# Altium schematic sheet bminator.SchDoc (continued)

## Library data of the parts
C1-C4 C11-C15 C44 C46 C48 = GRT033C71C104KE01D: CAP CER 100NF 16V X7S 0201 AEC-Q200; Manufacturer: Murata; Category: Ceramic Capacitors; Component Kind: Standard; Manufacturer Part Number: GRT033C71C104KE01D; Pricing 1: 1=0.10000, 10=0.04400, 50=0.04400, 100=0.02000, 1000=0.01100, 10000=0.01000 (USD); RoHS: Yes; Stock 1: 277440; Supplier 1: Mouser; Supplier Part Number 1: 81-GRT033C71C104KE1D; Library Name: ddln-passives.SchLib
C5-C8 C37 C38 = GRT155R60J475ME13D: CAP CER 4.7UF 6.3V X5R 0402 AEC-Q200; Manufacturer: Murata; Tolerance: 20%; Capacitance: 4.7uF; Case Code (Imperial): 0402; Case Code (Metric): 1005; Case/Package: 0402; Category: Ceramic Capacitors; Component Kind: Standard; ComponentLink1Description: http://search.murata.co.jp/Ceramy/image/img/A01X/G101/ENG/GRT155R60J475ME13-01.pdf?src-supplier=Digi-Key; ComponentLink1URL: http://search.murata.co.jp/Ceramy/image/img/A01X/G101/ENG/GRT155R60J475ME13-01.pdf?src-supplier=Digi-Key; ComponentLink2Description: https://search.murata.co.jp/Ceramy/image/img/A01X/partnumbering_e_02.pdf?src-supplier=Digi-Key; ComponentLink2URL: https://search.murata.co.jp/Ceramy/image/img/A01X/partnumbering_e_02.pdf?src-supplier=Digi-Key; Length: 0.039inch; Manufacturer Part Number: GRT155R60J475ME13D; Max Operating Temperature: 85°C; Min Operating Temperature: -55°C; Mount: Surface Mount; Packaging: Tape and Reel; Pricing 1: 10000=0.04860, 20000=0.03920, 30000=0.03890 (USD); Ratings: AEC-Q200; RoHS: No; Stock 1: 10000; Supplier 1: Future Electronics; Supplier Part Number 1: 2131604; Thickness: 0.022inch; Value: 4.7µF; Voltage Rating: 6.3V; Width: 0.02inch; Library Name: ddln-passives.SchLib
C9 C10 = GRT0335C1E270JA02D: CAP CER 27pF 25V NP0 0201; Manufacturer: Murata; Category: Ceramic Capacitors; Component Kind: Standard; Manufacturer Part Number: GRT0335C1E270JA02D; Pricing 1: 1=0.10000, 10=0.04400, 50=0.04400, 100=0.02000, 1000=0.01100, 10000=0.01000 (USD); RoHS: Yes; Stock 1: 277440; Supplier 1: Mouser; Supplier Part Number 1: 81-GRT033C71C104KE1D; Library Name: ddln-paul.SchLib
C16-C18 C26-C28 = 060-00355-000: CAP,CER,X5R,10%,50V,0805,10UF ROHS; Manufacturer: Murata; Manufacturer P/N: GRM21BR61H106KE43L; Value (nF): 10000; Value (pF): 10000000; Value (µF): 10; Avidyne P/N: 060-00355-000; Component Kind: Standard; Package: C0805; TOLERANCE: 10%; Value: 10µF; Library Name: ddln-paul.SchLib
C19 C29 = 060-00348-000: CAP, CER, SMT,50V, 10%, X5R, 0603, 2.2uf; Manufacturer: Taiyo Yuden; Manufacturer P/N: UMK107BBJ225KA-T; Value (nF): 2200; Value (pF): 2200000; Value (µF): 2.2; Avidyne P/N: 060-00348-000; Component Kind: Standard; Cost: 0.0696; Package: C0603; TOLERANCE: 10%; Value: 2.2µF; Library Name: ddln-paul.SchLib
C20-C23 = GRT188R61C106KE13D: CAP CER 10UF 16V X5R 0603 AEC-Q200; Manufacturer: Murata; Tolerance: 10%; Capacitance: 10uF; Case Code (Imperial): 0603; Case Code (Metric): 1608; Case/Package: 0603; Category: Ceramic Capacitors; Component Kind: Standard; ComponentLink1Description: http://search.murata.co.jp/Ceramy/image/img/A01X/G101/ENG/GRT188R61C106KE13-01.pdf?src-supplier=Digi-Key; ComponentLink1URL: http://search.murata.co.jp/Ceramy/image/img/A01X/G101/ENG/GRT188R61C106KE13-01.pdf?src-supplier=Digi-Key; Length: 0.063inch; Manufacturer Part Number: GRT188R61C106KE13D; Max Operating Temperature: 85°C; Min Operating Temperature: -55°C; Mount: Surface Mount; Packaging: Tape and Reel; Pricing 1: 1=0.44000, 10=0.30200, 50=0.21180, 100=0.18150, 500=0.13612, 1000=0.11495 (USD); Ratings: AEC-Q200; RoHS: Yes; Stock 1: 99001; Supplier 1: Digi-Key; Supplier Part Number 1: 490-12317-1-ND; Thickness: 0.035inch; Voltage Rating: 16V; Width: 0.031inch; Library Name: ddln-passives.SchLib
C24 = 064-00028-025: CAP RF, HIQ, NPO, +/- 1%, 10GHZ, 200, 100V, 0603, 150PF, ROHS; Manufacturer: Vishay; Manufacturer P/N: VJ0603D151FXBA; Value (nF): 0.15; Value (pF): 150; Value (µF): 0.00015; Avidyne P/N: 064-00028-025; Component Kind: Standard; Cost: 0.18353; Package: C0603; TOLERANCE: 1%; Value: 150pF; Library Name: ddln-paul.SchLib
C25 = 060-00353-000: CAP,CER,X7R,10%,100V,0805,1.0UF ROHS; Manufacturer: AVX; Manufacturer P/N: 08051C105K4Z2A; Value (nF): 1000; Value (pF): 1000000; Value (µF): 1; Avidyne P/N: 060-00353-000; Component Kind: Standard; Cost: 0.11244; Library Name: Capacitors.SCHLIB; Package: C0805; TOLERANCE: 10%; Value: 1.0µF
C30 = 060-00158-003: CAP CER 10UF 50V 10% X7R 1210; Manufacturer: Murata; Manufacturer P/N: GRM32ER71H106KA12L; Value: 10µF; Value (nF): 10000; Value (pF): 10000000; Value (µF): 10; Avidyne P/N: 060-00158-003; Component Kind: Standard; Cost: 0.18564; Package: C1210; TOLERANCE: 10%; Library Name: ddln-paul.SchLib
C31 C32 = 060-00355-000: CAP,CER,X5R,10%,50V,0805,10UF ROHS; Manufacturer: Murata; Manufacturer P/N: GRM21BR61H106KE43L; Value: 10µF; Value (nF): 10000; Value (pF): 10000000; Value (µF): 10; Avidyne P/N: 060-00355-000; Component Kind: Standard; Package: C0805; TOLERANCE: 10%; Library Name: ddln-paul.SchLib
C33-C36 = GCM155R71H104KE02J: CAP CER 0.1UF 50V X7R 0402 AEC-Q200; Manufacturer: Murata Electronics; Capacitance: 0.1µF; Tolerance: ±10%; Applications: Automotive; Component Kind: Standard; ComponentLink1Description: Datasheet; ComponentLink1URL: https://search.murata.co.jp/Ceramy/image/img/A01X/G101/ENG/GCM155R71H104KE02-01.pdf; ComponentLink2Description: DigiKey Link; ComponentLink2URL: http://digikey.com/product-detail/en/murata-electronics/GCM155R71H104KE02J/490-14514-1-ND/6606120; Device Package: 0402 (1005 Metric); LastUpdated: 02/09/2020 01:02:03; Lifecycle Status: Active; Minimum Order: 1; Mounting Type: Surface Mount, MLCC; Operating Temperature: -55°C ~ 125°C; Package / Case: 0402 (1005 Metric); Packaging: TapeAndReel; Part Number: GCM155R71H104KE02J; Part Status: Active; PartId: 11356; Price: 0.01; Ratings: AEC-Q200; Series: GCM; Size / Dimension: 0.039" L x 0.020" W (1.00mm x 0.50mm); Supplier 1: DigiKey; Supplier Part Number 1: 490-14514-1-ND; Temperature Coefficient: X7R; Thickness (Max): 0.022" (0.55mm); Value: 100nF; Library Name: ddln-passives.SchLib
  C33-C35: Voltage - Rated: 50V
C39 C42 C43 = GCM155C71A105ME38D: CAP CER 1UF 10V X7S 0402 AEC-Q200; Manufacturer: Murata; Category: Ceramic Capacitors; Component Kind: Standard; ComponentLink1Description: https://search.murata.co.jp/Ceramy/image/img/A01X/G101/ENG/GCM155C71A105ME38-01.pdf?src-supplier=Digi-Key; ComponentLink1URL: https://search.murata.co.jp/Ceramy/image/img/A01X/G101/ENG/GCM155C71A105ME38-01.pdf?src-supplier=Digi-Key; Manufacturer Part Number: GCM155C71A105ME38D; Pricing 1: 1=0.14000, 10=0.09700, 50=0.05520, 100=0.04580, 500=0.03210, 1000=0.02658, 2500=0.02474, 5000=0.02263 (USD); RoHS: Yes; RoHS Compliant: Yes; Stock 1: 84115; Supplier 1: Digi-Key; Supplier Part Number 1: 490-18202-1-ND; Value: 1µF; Library Name: ddln-passives.SchLib
C40 C41 C45 = 02013C103MAT2A: CAP CER 10NF 25V X7R 0201 AEC-Q200; Manufacturer: Murata; Manufacturer Part Number: GRT033C71C104KE01D; Category: Ceramic Capacitors; Component Kind: Standard; Pricing 1: 1=0.10000, 10=0.04400, 50=0.04400, 100=0.02000, 1000=0.01100, 10000=0.01000 (USD); RoHS: Yes; Stock 1: 277440; Supplier 1: Mouser; Supplier Part Number 1: 81-GRT033C71C104KE1D; Library Name: ddln-paul.SchLib
C47 = GRM155R71H472KA01D: CAP CER 4700PF 50V X7R 0402; Manufacturer: Murata Electronics; Tolerance: ±10%; Applications: General Purpose; Capacitance: 4700pF; Component Kind: Standard; ComponentLink1Description: Datasheet; ComponentLink1URL: https://www.murata.com/~/media/webrenewal/support/library/catalog/products/capacitor/mlcc/c02e.ashx?la=en-us; ComponentLink2Description: DigiKey Link; ComponentLink2URL: http://digikey.com/product-detail/en/murata-electronics/GRM155R71H472KA01D/490-1308-1-ND/587946; Device Package: 0402 (1005 Metric); LastUpdated: 02/09/2020 01:02:19; Lifecycle Status: Active; Minimum Order: 1; Mounting Type: Surface Mount, MLCC; Operating Temperature: -55°C ~ 125°C; Package / Case: 0402 (1005 Metric); Packaging: CutTape; Part Number: GRM155R71H472KA01D; Part Status: Active; PartId: 4000; Price: 0.10; Series: GRM; Size / Dimension: 0.039" L x 0.020" W (1.00mm x 0.50mm); Supplier 1: DigiKey; Supplier Part Number 1: 490-1308-1-ND; Temperature Coefficient: X7R; Thickness (Max): 0.022" (0.55mm); Value: 4700pF; Voltage - Rated: 50V; Library Name: ddln-passives.SchLib
D1 = VLMS1500-GS08: LED RED 0402 SMD; Manufacturer: Vishay; Dominant Wavelength: 631nm; Forward Current: 30mA; Lens Style: Rectangular,Square; Luminous Intensity: 54mcd; Number of LEDs: 1; Power Rating: 75mW; Viewing Angle: 130°; Case Code (Imperial): 0402; Case Code (Metric): 1005; Case/Package: 0402; Category: LEDs; Color: Red; Component Kind: Standard; ComponentLink1Description: https://datasheet.ciiva.com/13945/vlmo1500-13945369.pdf?src-supplier=Digi-Key; ComponentLink1URL: https://datasheet.ciiva.com/13945/vlmo1500-13945369.pdf?src-supplier=Digi-Key; ComponentLink2Description: https://datasheet.ciiva.com/13945/vlmo1500-13945367.pdf?src-supplier=Digi-Key; ComponentLink2URL: https://datasheet.ciiva.com/13945/vlmo1500-13945367.pdf?src-supplier=Digi-Key; ComponentLink3Description: https://datasheet.ciiva.com/13945/vlmo1500-13945368.pdf?src-supplier=Digi-Key; ComponentLink3URL: https://datasheet.ciiva.com/13945/vlmo1500-13945368.pdf?src-supplier=Digi-Key; Height: 0.35mm; Illumination Color: Red; Lead Free: Lead Free; Length: 1mm; Manufacturer Part Number: VLMS1500-GS08; Max Operating Temperature: 85°C; Min Operating Temperature: -30°C; Mount: Surface Mount; Number of Pins: 2; Package Quantity: 1; Packaging: Tape and Reel; Pricing 1: 1=0.43000, 10=0.32200, 100=0.18250, 500=0.12088, 1000=0.09267 (USD); Radiation Hardening: No; REACH SVHC: Unknown; RoHS: Yes; RoHS Compliant: Yes; Series: CHipLED; Stock 1: 9946; Supplier 1: Digi-Key; Supplier Part Number 1: VLMS1500-GS08CT-ND; Wavelength: 631nm; Width: 0.5mm; Library Name: ddln-diodes-transistors.SchLib
D2 = 023-00382-000: DIODE SCHOTTKY 60V 2A CFP3; Manufacturer: NXP; Manufacturer P/N: PMEG6020AELRX; Value: PMEG6020; Vf: 670mV @ 2A; Wattage: 1W; Avidyne P/N: 023-00382-000; Component Kind: Standard; Cost: 0.09842; Package: SOD-123W; Type: Schottky; Library Name: ddln-paul.SchLib
FB1-FB4 = 082-00033-000: FERRITE,100MHZ,0402,120OHM ROHS; Manufacturer: TDK; Manufacturer P/N: MPZ1005S121HT000; Impedance: 120 Ohm @ 100MHz; Value: MPZ1005S121HT000; Avidyne P/N: 082-00033-000; Component Kind: Standard; Cost: 0.03168; Package: L0402; Simulation: FB; Library Name: ddln-paul.SchLib
IC1 = STM32L431: Integrated Circuit; Manufacturer_Name: STMicroelectronics; Manufacturer_Part_Number: STM32F103C8T6TR; Arrow Part Number: STM32F103C8T6TR; Arrow Price/Stock: https://www.arrow.com/en/products/stm32f103c8t6tr/stmicroelectronics?region=europe; Component Kind: Standard; Datasheet Link: http://media.digikey.com/pdf/Data%20Sheets/ST%20Microelectronics%20PDFS/STM32F103x8,B.pdf; Height: 1.6mm; Mouser Part Number: 511-STM32F103C8T6TR; Mouser Price/Stock: https://www.mouser.co.uk/ProductDetail/STMicroelectronics/STM32F103C8T6TR?qs=5HwTSiuA5HDxFj62n06yag%3D%3D; Library Name: ddln-paul.SchLib
IC2 = LMQ66410MC5RXBRQ1: Integrated Circuit; Manufacturer_Name: Texas Instruments; Arrow Part Number: LMQ66410MC5RXBRQ1; Arrow Price/Stock: https://www.arrow.com/en/products/lmq66410mc5rxbrq1/texas-instruments?region=nac; Component Kind: Standard; Datasheet Link: https://www.ti.com/lit/ds/symlink/lmq66410.pdf?ts=1695019454011&ref_url=https%253A%252F%252Fwww.ti.com%252Fpower-management%252Fnon-isolated-dc-dc-switching-regulators%252Fstep-down-buck%252Fbuck-converter-integrated-switch%252Fproducts.html; Height: 1.05mm; Manufacturer_Part_Number: LMQ66410MC5RXBRQ1; Mouser Part Number: 595-MQ66410MC5RXBRQ1; Mouser Price/Stock: https://www.mouser.co.uk/ProductDetail/Texas-Instruments/LMQ66410MC5RXBRQ1?qs=1Kr7Jg1SGW8IS8%252BO4rEnbw%3D%3D; Library Name: ddln-paul.SchLib
J1 = 3-PIN-POGO: Made for pressing spring loaded pins for CAN.; Manufacturer_Name: Molex; Component Kind: Standard; Datasheet Link: http://www.farnell.com/datasheets/2695377.pdf; Height: 8.38mm; Manufacturer_Part_Number: 22-28-4030; Mouser Part Number: 538-22-28-4030; Mouser Price/Stock: https://www.mouser.co.uk/ProductDetail/Molex/22-28-4030?qs=d9fIA1aNikRAvd6m1950OA%3D%3D; Library Name: ddln-paul.SchLib
J2 = 6-PIN-POGO: Made for pressing spring loaded pins against for programming; Manufacturer_Name: Molex; Component Kind: Standard; Datasheet Link: http://www.farnell.com/datasheets/2695377.pdf; Height: 8.38mm; Manufacturer_Part_Number: 22-28-4030; Mouser Part Number: 538-22-28-4030; Mouser Price/Stock: https://www.mouser.co.uk/ProductDetail/Molex/22-28-4030?qs=d9fIA1aNikRAvd6m1950OA%3D%3D; Library Name: ddln-paul.SchLib
J3 = HR10A-10R-12PB(72): 12 Position Circular Connector Receptacle, Male Pins Solder; MF: Hirose Electric; MP: HR10A-10R-12PB_72_; Component Kind: Standard; Digi-Key_Part_Number: HR1650-ND; Digi-Key_Purchase_URL: https://www.digikey.kr/product-detail/en/hirose-electric-co-ltd/HR10A-10R-12PB_72_/HR1650-ND/1095508?utm_source=snapeda&utm_medium=aggregator&utm_campaign=symbol; Package: None; SnapEDA_Link: https://www.snapeda.com/parts/HR10A-10R-12PB_72_/Hirose%20Electric%20Co%20Ltd/view-part/493204/?ref=snap; Library Name: ddln-paul.SchLib
L1 = LQH5BPZ4R7NT0L: Inductor; Manufacturer_Name: Murata Electronics; Arrow Part Number: LQH5BPZ4R7NT0L; Arrow Price/Stock: https://www.arrow.com/en/products/lqh5bpz4r7nt0l/murata-manufacturing; Component Kind: Standard; Datasheet Link: https://psearch.en.murata.com/inductor/product/LQH5BPZ4R7NT0%23.html; Height: 2.2mm; Manufacturer_Part_Number: LQH5BPZ4R7NT0L; Mouser Part Number: 81-LQH5BPZ4R7NT0L; Mouser Price/Stock: https://www.mouser.co.uk/ProductDetail/Murata-Electronics/LQH5BPZ4R7NT0L?qs=Ey7%2FXF42M3e2lK8EunBAqA%3D%3D; Library Name: ddln-paul.SchLib
L2 = LQH32PBR47NN0L: Inductor; Manufacturer_Name: Murata Electronics; Manufacturer_Part_Number: LQH5BPZ4R7NT0L; Arrow Part Number: LQH5BPZ4R7NT0L; Arrow Price/Stock: https://www.arrow.com/en/products/lqh5bpz4r7nt0l/murata-manufacturing; Component Kind: Standard; Datasheet Link: https://psearch.en.murata.com/inductor/product/LQH5BPZ4R7NT0%23.html; Height: 2.2mm; Mouser Part Number: 81-LQH5BPZ4R7NT0L; Mouser Price/Stock: https://www.mouser.co.uk/ProductDetail/Murata-Electronics/LQH5BPZ4R7NT0L?qs=Ey7%2FXF42M3e2lK8EunBAqA%3D%3D; Library Name: ddln-paul.SchLib
L3 = 080-00283-000: INDUCT ARRAY 2 COIL 3.3UH SMD; Core: Metal Composite; Current: 1.66A; Current_Sat: 2.11A; TOLERANCE: 20%; Avidyne P/N: 080-00283-000; Component Kind: Standard; Cost: 0.51750; Library Name: E1V2 Schlib.SchLib; Package: 0.299" L x 0.299" W (7.60mm x 7.60mm); Value: 3.3uH
R1 R17 = ERJ-1GNF1002C: RES SMD 10K OHM 1% 1/20W 0201; Manufacturer: Panasonic Electronic Components; Power (Watts): 0.05W, 1/20W; Resistance: 10 kOhms; Temperature Coefficient: ±200ppm/°C; Component Kind: Standard; ComponentLink1Description: Datasheet; ComponentLink1URL: https://industrial.panasonic.com/cdbs/www-data/pdf/RDA0000/AOA0000C304.pdf; ComponentLink2Description: DigiKey Link; ComponentLink2URL: http://digikey.com/product-detail/en/panasonic-electronic-components/ERJ-1GNF1002C/P122414CT-ND/7389440; Composition: Thick Film; Device Package: 0201 (0603 Metric); Features: Automotive AEC-Q200; Height - Seated (Max): 0.010" (0.26mm); LastUpdated: 02/09/2020 01:06:16; Lifecycle Status: Active; Minimum Order: 1; Number of Terminations: 2; Operating Temperature: -55°C ~ 125°C; Package / Case: 0201 (0603 Metric); Packaging: TapeAndReel; Part Number: ERJ-1GNF1002C; Part Status: Active; PartId: 2296; Price: 0.01; Ratings: AEC-Q200; Series: ERJ; Size / Dimension: 0.024" L x 0.012" W (0.60mm x 0.30mm); Supplier 1: DigiKey; Supplier Device Package: 0201; Supplier Part Number 1: P122414CT-ND; Tolerance: ±1%; Value: 10 kΩ; Library Name: ddln-res-0201.SchLib
R2 R8 = ERJ-1GEF1001C: RES SMD 1K OHM 1% 1/20W 0201; Manufacturer: Panasonic Electronic Components; Power (Watts): 0.05W, 1/20W; Resistance: 1 kOhms; Temperature Coefficient: ±200ppm/°C; Component Kind: Standard; ComponentLink1Description: Datasheet; ComponentLink1URL: //media.digikey.com/pdf/Data%20Sheets/Panasonic%20Resistors%20Thermistors%20PDFs/ERJ-G,R,E_Series_Rev_Oct_2014.pdf; ComponentLink2Description: DigiKey Link; ComponentLink2URL: http://digikey.com/product-detail/en/panasonic-electronic-components/ERJ-1GEF1001C/P1-00KABCT-ND/525077; Composition: Thick Film; Height - Seated (Max): 0.010" (0.26mm); LastUpdated: 02/09/2020 01:06:09; Lifecycle Status: Active; Minimum Order: 1; Number of Terminations: 2; Package / Case: 0201 (0603 Metric); Packaging: CutTape; Part Number: ERJ-1GEF1001C; PartId: 57331; Price: 0.10; Series: ERJ; Size / Dimension: 0.024" L x 0.012" W (0.60mm x 0.30mm); Supplier 1: DigiKey; Supplier Device Package: 0201; Supplier Part Number 1: P1.00KABCT-ND; Tolerance: ±1%; Value: 1 kΩ; Library Name: ddln-res-0201.SchLib
R3 = RMCF0402FT820R: RES 820 OHM 1% 1/16W 0402; Manufacturer: Stackpole Electronics Inc; Power (Watts): 0.063W, 1/16W; Temperature Coefficient: ±100ppm/°C; Component Kind: Standard; ComponentLink1Description: Datasheet; ComponentLink1URL: https://www.seielect.com/catalog/sei-rmcf_rmcp.pdf; ComponentLink2Description: DigiKey Link; ComponentLink2URL: http://digikey.com/product-detail/en/stackpole-electronics-inc/RMCF0402FT820R/RMCF0402FT820RCT-ND/6052949; Composition: Thick Film; Device Package: 0402 (1005 Metric); Features: Automotive AEC-Q200; Height - Seated (Max): 0.016" (0.40mm); LastUpdated: 02/09/2020 01:07:36; Lifecycle Status: Active; Minimum Order: 1; Number of Terminations: 2; Operating Temperature: -55°C ~ 155°C; Package / Case: 0402 (1005 Metric); Packaging: CutTape; Part Number: RMCF0402FT820R; Part Status: Active; PartId: 17897; Price: 0.10; Ratings: AEC-Q200; Resistance: 820 Ω; Series: RMCF; Size / Dimension: 0.039" L x 0.020" W (1.00mm x 0.50mm); Supplier 1: DigiKey; Supplier Device Package: 0402; Supplier Part Number 1: RMCF0402FT820RCT-ND; Tolerance: ±1%; Value: 820 Ω; Library Name: ddln-res-0402.SchLib
R4 R15 = RMCF0402FT475R: RES 475 OHM 1% 1/16W 0402; Manufacturer: Stackpole Electronics Inc; Power (Watts): 0.063W, 1/16W; Temperature Coefficient: ±100ppm/°C; Component Kind: Standard; ComponentLink1Description: Datasheet; ComponentLink1URL: https://www.seielect.com/catalog/sei-rmcf_rmcp.pdf; ComponentLink2Description: DigiKey Link; ComponentLink2URL: http://digikey.com/product-detail/en/stackpole-electronics-inc/RMCF0402FT475R/RMCF0402FT475RCT-ND/2417702; Composition: Thick Film; Device Package: 0402 (1005 Metric); Features: Automotive AEC-Q200; Height - Seated (Max): 0.016" (0.40mm); LastUpdated: 02/09/2020 01:07:52; Lifecycle Status: Active; Minimum Order: 1; Number of Terminations: 2; Operating Temperature: -55°C ~ 155°C; Package / Case: 0402 (1005 Metric); Packaging: CutTape; Part Number: RMCF0402FT475R; Part Status: Active; PartId: 10933; Price: 0.10; Ratings: AEC-Q200; Resistance: 475 Ω; Series: RMCF; Size / Dimension: 0.039" L x 0.020" W (1.00mm x 0.50mm); Supplier 1: DigiKey; Supplier Device Package: 0402; Supplier Part Number 1: RMCF0402FT475RCT-ND; Tolerance: ±1%; Value: 475 Ω; Library Name: ddln-res-0402.SchLib
R5 = 070-00605-001: RES, THICK FILM CHIP, 1W, 1%, 1206, 0.01 OHM; Manufacturer: Vishay-Dale Intertechnology, Inc; Manufacturer P/N: WSLP1206R0100FEA; Avidyne P/N: 070-00605-001; Component Kind: Standard; Cost: 0.25; Package: R1206; Simulation: R; Library Name: ddln-paul.SchLib
R6 = RMCF0402FT301R: RES 301 OHM 1% 1/16W 0402; Manufacturer: Stackpole Electronics Inc; Power (Watts): 0.063W, 1/16W; Temperature Coefficient: ±100ppm/°C; Component Kind: Standard; ComponentLink1Description: Datasheet; ComponentLink1URL: https://www.seielect.com/catalog/sei-packaging.pdf; ComponentLink2Description: DigiKey Link; ComponentLink2URL: http://digikey.com/product-detail/en/stackpole-electronics-inc/RMCF0402FT301R/RMCF0402FT301RCT-ND/4250676; Composition: Thick Film; Device Package: 0402 (1005 Metric); Features: Automotive AEC-Q200; Height - Seated (Max): 0.016" (0.40mm); LastUpdated: 02/09/2020 01:07:36; Lifecycle Status: Active; Minimum Order: 1; Number of Terminations: 2; Operating Temperature: -55°C ~ 155°C; Package / Case: 0402 (1005 Metric); Packaging: CutTape; Part Number: RMCF0402FT301R; Part Status: Active; PartId: 17904; Price: 0.10; Ratings: AEC-Q200; Resistance: 301 Ω; Series: RMCF; Size / Dimension: 0.039" L x 0.020" W (1.00mm x 0.50mm); Supplier 1: DigiKey; Supplier Device Package: 0402; Supplier Part Number 1: RMCF0402FT301RCT-ND; Tolerance: ±1%; Value: 301 Ω; Library Name: ddln-res-0402.SchLib
R7 = RMCF0402ZT0R00: RES 0 OHM JUMPER 1/16W 0402; Manufacturer: Stackpole Electronics Inc; Power (Watts): 0.063W, 1/16W; Component Kind: Standard; ComponentLink1Description: Datasheet; ComponentLink1URL: https://www.seielect.com/catalog/sei-rmcf_rmcp.pdf; ComponentLink2Description: DigiKey Link; ComponentLink2URL: http://digikey.com/product-detail/en/stackpole-electronics-inc/RMCF0402ZT0R00/RMCF0402ZT0R00CT-ND/1942941; Composition: Thick Film; Device Package: 0402 (1005 Metric); Features: Automotive AEC-Q200; Height - Seated (Max): 0.016" (0.40mm); LastUpdated: 02/09/2020 01:07:36; Lifecycle Status: Active; Minimum Order: 1; Number of Terminations: 2; Operating Temperature: -55°C ~ 155°C; Package / Case: 0402 (1005 Metric); Packaging: CutTape; Part Number: RMCF0402ZT0R00; Part Status: Active; PartId: 17627; Price: 0.10; Ratings: AEC-Q200; Resistance: 0 Ω; Series: RMCF; Size / Dimension: 0.039" L x 0.020" W (1.00mm x 0.50mm); Supplier 1: DigiKey; Supplier Device Package: 0402; Supplier Part Number 1: RMCF0402ZT0R00CT-ND; Tolerance: Jumper; Value: 0 Ω; Library Name: ddln-res-0402.SchLib
R9 = ERJ-1GNF1212C: RES SMD 12.1K OHM 1% 1/20W 0201; Manufacturer: Panasonic Electronic Components; Power (Watts): 0.05W, 1/20W; Resistance: 12.1 kOhms; Temperature Coefficient: ±200ppm/°C; Component Kind: Standard; ComponentLink1Description: Datasheet; ComponentLink1URL: https://industrial.panasonic.com/cdbs/www-data/pdf/RDA0000/AOA0000C304.pdf; ComponentLink2Description: DigiKey Link; ComponentLink2URL: http://digikey.com/product-detail/en/panasonic-electronic-components/ERJ-1GNF1212C/P122698CT-ND/8342335; Composition: Thick Film; Device Package: 0201 (0603 Metric); Features: Automotive AEC-Q200; Height - Seated (Max): 0.010" (0.26mm); LastUpdated: 02/09/2020 01:06:16; Lifecycle Status: Active; Minimum Order: 1; Number of Terminations: 2; Operating Temperature: -55°C ~ 125°C; Package / Case: 0201 (0603 Metric); Packaging: TapeAndReel; Part Number: ERJ-1GNF1212C; Part Status: Active; PartId: 2364; Price: 0.01; Ratings: AEC-Q200; Series: ERJ; Size / Dimension: 0.024" L x 0.012" W (0.60mm x 0.30mm); Supplier 1: DigiKey; Supplier Device Package: 0201; Supplier Part Number 1: P122698CT-ND; Tolerance: ±1%; Value: 12.1 kΩ; Library Name: ddln-res-0201.SchLib
R10 = RMCF0402FT1K00: RES 1K OHM 1% 1/16W 0402; Manufacturer: Stackpole Electronics Inc; Power (Watts): 0.063W, 1/16W; Temperature Coefficient: ±100ppm/°C; Component Kind: Standard; ComponentLink1Description: Datasheet; ComponentLink1URL: https://www.seielect.com/catalog/sei-rmcf_rmcp.pdf; ComponentLink2Description: DigiKey Link; ComponentLink2URL: http://digikey.com/product-detail/en/stackpole-electronics-inc/RMCF0402FT1K00/RMCF0402FT1K00CT-ND/1942920; Composition: Thick Film; Device Package: 0402 (1005 Metric); Features: Automotive AEC-Q200; Height - Seated (Max): 0.016" (0.40mm); LastUpdated: 02/09/2020 01:07:28; Lifecycle Status: Active; Minimum Order: 1; Number of Terminations: 2; Operating Temperature: -55°C ~ 155°C; Package / Case: 0402 (1005 Metric); Packaging: CutTape; Part Number: RMCF0402FT1K00; Part Status: Active; PartId: 20544; Price: 0.10; Ratings: AEC-Q200; Resistance: 1 kΩ; Series: RMCF; Size / Dimension: 0.039" L x 0.020" W (1.00mm x 0.50mm); Supplier 1: DigiKey; Supplier Device Package: 0402; Supplier Part Number 1: RMCF0402FT1K00CT-ND; Tolerance: ±1%; Value: 1 kΩ; Library Name: ddln-res-0402.SchLib
R11 = ERJ-1GNF1003C: RES SMD 100K OHM 1% 1/20W 0201; Manufacturer: Panasonic Electronic Components; Power (Watts): 0.05W, 1/20W; Temperature Coefficient: ±200ppm/°C; Component Kind: Standard; ComponentLink1Description: Datasheet; ComponentLink1URL: https://industrial.panasonic.com/cdbs/www-data/pdf/RDA0000/AOA0000C304.pdf; ComponentLink2Description: DigiKey Link; ComponentLink2URL: http://digikey.com/product-detail/en/panasonic-electronic-components/ERJ-1GNF1003C/P122655CT-ND/8342249; Composition: Thick Film; Device Package: 0201 (0603 Metric); Features: Automotive AEC-Q200; Height - Seated (Max): 0.010" (0.26mm); LastUpdated: 02/09/2020 01:06:22; Lifecycle Status: Active; Minimum Order: 1; Number of Terminations: 2; Operating Temperature: -55°C ~ 125°C; Package / Case: 0201 (0603 Metric); Packaging: TapeAndReel; Part Number: ERJ-1GNF1003C; Part Status: Active; PartId: 819; Price: 0.01; Ratings: AEC-Q200; Series: ERJ; Size / Dimension: 0.024" L x 0.012" W (0.60mm x 0.30mm); Supplier 1: DigiKey; Supplier Device Package: 0201; Supplier Part Number 1: P122655CT-ND; Tolerance: ±1%; Value: 100 kΩ; Library Name: ddln-res-0201.SchLib
R12 = ERJ-1GNF1003C: RES SMD 100K OHM 1% 1/20W 0201; Manufacturer: Panasonic Electronic Components; Power (Watts): 0.05W, 1/20W; Resistance: 100 kOhms; Temperature Coefficient: ±200ppm/°C; Component Kind: Standard; ComponentLink1Description: Datasheet; ComponentLink1URL: https://industrial.panasonic.com/cdbs/www-data/pdf/RDA0000/AOA0000C304.pdf; ComponentLink2Description: DigiKey Link; ComponentLink2URL: http://digikey.com/product-detail/en/panasonic-electronic-components/ERJ-1GNF1003C/P122655CT-ND/8342249; Composition: Thick Film; Device Package: 0201 (0603 Metric); Features: Automotive AEC-Q200; Height - Seated (Max): 0.010" (0.26mm); LastUpdated: 02/09/2020 01:06:22; Lifecycle Status: Active; Minimum Order: 1; Number of Terminations: 2; Operating Temperature: -55°C ~ 125°C; Package / Case: 0201 (0603 Metric); Packaging: TapeAndReel; Part Number: ERJ-1GNF1003C; Part Status: Active; PartId: 819; Price: 0.01; Ratings: AEC-Q200; Series: ERJ; Size / Dimension: 0.024" L x 0.012" W (0.60mm x 0.30mm); Supplier 1: DigiKey; Supplier Device Package: 0201; Supplier Part Number 1: P122655CT-ND; Tolerance: ±1%; Value: 100 kΩ; Library Name: ddln-res-0201.SchLib
R13 = ERJ-1GNF2211C: RES SMD 2.21K OHM 1% 1/20W 0201; Manufacturer: Panasonic Electronic Components; Power (Watts): 0.05W, 1/20W; Resistance: 2.21 kOhms; Temperature Coefficient: ±200ppm/°C; Component Kind: Standard; ComponentLink1Description: Datasheet; ComponentLink1URL: https://industrial.panasonic.com/cdbs/www-data/pdf/RDA0000/AOA0000C304.pdf; ComponentLink2Description: DigiKey Link; ComponentLink2URL: http://digikey.com/product-detail/en/panasonic-electronic-components/ERJ-1GNF2211C/P122836CT-ND/8342611; Composition: Thick Film; Device Package: 0201 (0603 Metric); Features: Automotive AEC-Q200; Height - Seated (Max): 0.010" (0.26mm); LastUpdated: 02/09/2020 01:06:11; Lifecycle Status: Active; Minimum Order: 1; Number of Terminations: 2; Operating Temperature: -55°C ~ 125°C; Package / Case: 0201 (0603 Metric); Packaging: TapeAndReel; Part Number: ERJ-1GNF2211C; Part Status: Active; PartId: 4111; Price: 0.01; Ratings: AEC-Q200; Series: ERJ; Size / Dimension: 0.024" L x 0.012" W (0.60mm x 0.30mm); Supplier 1: DigiKey; Supplier Device Package: 0201; Supplier Part Number 1: P122836CT-ND; Tolerance: ±1%; Value: 2.21 kΩ; Library Name: ddln-res-0201.SchLib
R14 = ERJ-1GNF2002C: RES SMD 20K OHM 1% 1/20W 0201; Manufacturer: Panasonic Electronic Components; Power (Watts): 0.05W, 1/20W; Resistance: 20 kOhms; Temperature Coefficient: ±200ppm/°C; Component Kind: Standard; ComponentLink1Description: Datasheet; ComponentLink1URL: https://industrial.panasonic.com/cdbs/www-data/pdf/RDA0000/AOA0000C304.pdf; ComponentLink2Description: DigiKey Link; ComponentLink2URL: http://digikey.com/product-detail/en/panasonic-electronic-components/ERJ-1GNF2002C/P122813CT-ND/8342565; Composition: Thick Film; Device Package: 0201 (0603 Metric); Features: Automotive AEC-Q200; Height - Seated (Max): 0.010" (0.26mm); LastUpdated: 02/09/2020 01:06:11; Lifecycle Status: Active; Minimum Order: 1; Number of Terminations: 2; Operating Temperature: -55°C ~ 125°C; Package / Case: 0201 (0603 Metric); Packaging: TapeAndReel; Part Number: ERJ-1GNF2002C; Part Status: Active; PartId: 4105; Price: 0.01; Ratings: AEC-Q200; Series: ERJ; Size / Dimension: 0.024" L x 0.012" W (0.60mm x 0.30mm); Supplier 1: DigiKey; Supplier Device Package: 0201; Supplier Part Number 1: P122813CT-ND; Tolerance: ±1%; Value: 20 kΩ; Library Name: ddln-res-0201.SchLib
R16 = ERJ-1GNF2742C: RES SMD 27.4K OHM 1% 1/20W 0201; Manufacturer: Panasonic Electronic Components; Power (Watts): 0.05W, 1/20W; Resistance: 27.4 kOhms; Temperature Coefficient: ±200ppm/°C; Component Kind: Standard; ComponentLink1Description: Datasheet; ComponentLink1URL: https://industrial.panasonic.com/cdbs/www-data/pdf/RDA0000/AOA0000C304.pdf; ComponentLink2Description: DigiKey Link; ComponentLink2URL: http://digikey.com/product-detail/en/panasonic-electronic-components/ERJ-1GNF2742C/P122892CT-ND/8342723; Composition: Thick Film; Device Package: 0201 (0603 Metric); Features: Automotive AEC-Q200; Height - Seated (Max): 0.010" (0.26mm); LastUpdated: 02/09/2020 01:06:16; Lifecycle Status: Active; Minimum Order: 1; Number of Terminations: 2; Operating Temperature: -55°C ~ 125°C; Package / Case: 0201 (0603 Metric); Packaging: TapeAndReel; Part Number: ERJ-1GNF2742C; Part Status: Active; PartId: 2321; Price: 0.01; Ratings: AEC-Q200; Series: ERJ; Size / Dimension: 0.024" L x 0.012" W (0.60mm x 0.30mm); Supplier 1: DigiKey; Supplier Device Package: 0201; Supplier Part Number 1: P122892CT-ND; Tolerance: ±1%; Value: 27.4 kΩ; Library Name: ddln-res-0201.SchLib
SJ1 = DualSolderJumper: Power Supply; Manufacturer_Name: RECOM Power; Manufacturer_Part_Number: R-78E3.3-1.0; Arrow Part Number: R-78E3.3-1.0; Arrow Price/Stock: https://www.arrow.com/en/products/r-78e3.3-1.0/recom-power?region=europe; Component Kind: Standard; Datasheet Link: https://componentsearchengine.com/Datasheets/1/R-78E3.3-1.0.pdf; Height: 10.9mm; Mouser Part Number: 919-R-78E3.3-1.0; Mouser Price/Stock: https://www.mouser.co.uk/ProductDetail/RECOM-Power/R-78E3.3-1.0?qs=D0iH%252BVFiYPOgLjjtWWnV0Q%3D%3D; Library Name: ddln-paul.SchLib
U1 = BME280: DIGITAL HUMIDITY, PRESSURE AND TEMPERATURE SENSOR<br>* Digital interface IÂ²C (up to 3.4 MHz) and SPI (3 and 4 wire, up to 10 MHz)* Supply voltage VDD main supply voltage range: 1.71 V to 3.6 V*VDDIO interface voltage range: 1.2 V to 3.6 V<br> Operating range -40â€|+85 Â°C, 0â€|100 % rel. humidity, 300â€|1100 hPa <br><br>  The library has been designed by<a href="https://www.facebook.com/groups/eaglecadsoftUK"> Richard Magdycz</a>; Availability: Unavailable; Component Kind: Standard; MP: BME280; Package: None; Price: None; Library Name: ddln-paul.SchLib
U2 = BMI088: High-performance 6-axis inertial sensor (accelerometer + gyroscope) <a href="https://pricing.snapeda.com/parts/BMI088/Bosch%20Sensortec/view-part?ref=eda">Check prices</a>; Availability: Unavailable; Component Kind: Standard; MP: BMI088; Package: None; Price: None; Library Name: ddln-paul.SchLib
U3 = 011-00530-000: IC REG BST SEPIC 4A 16MSOP; Manufacturer: Linear Technology; Manufacturer P/N: LT8364EMSE#PBF; Frequency: 300KHz~2MHz; Voltage-Input: 2.8V ~ 60V; Avidyne P/N: 011-00530-000; Component Kind: Standard; Cost: 2.12; Package: 16-TFSOP (0.118", 3.00mm Width) Exposed Pad, 12 Leads; Value: LT8364; Library Name: ddln-paul.SchLib
U4 = 011-00537-000: IC ANALOG, CURRENT MONITOR, 85V, I2C INTERFACE, VSSOP-10; Manufacturer: Texas Instruments; Manufacturer P/N: INA238AIDGS; Value: INA238; Voltage-Input: -0.3V ~ 85V; Avidyne P/N: 011-00537-000; Component Kind: Standard; Cost: 1.39; Package: VSSOP-10; Library Name: ddln-paul.SchLib
U5 = TCR13AGADJ,LF: IC REG LINEAR POS ADJ 1.3A 6WCSP; Manufacturer: Toshiba Semiconductor and Storage; Avidyne P/N: 011-00575-000; Voltage-Input: 6V; Voltage-Output: 0.55V - 3.6V; Component Kind: Standard; Cost: 0.1802; Manufacturer P/N: TCR13AGADJ,LF; Package: 6-WCSP (1.2x0.80); Value: TCR13AGADJ,LF; Library Name: ddln-paul.SchLib
Y1 = ECS-80-18-30B-AGN-TR: Crystal or Oscillator; Manufacturer_Name: ECS; Arrow Part Number: ECS-80-18-30B-AGN-TR; Arrow Price/Stock: https://www.arrow.com/en/products/ecs-80-18-30b-agn-tr/ecs-international?region=nac; Component Kind: Standard; Datasheet Link: http://www.ecsxtal.com/store/pdf/ecx_53b.pdf; Height: 0.85mm; Manufacturer_Part_Number: ECS-80-18-30B-AGN-TR; Mouser Part Number: 520-80-18-30B-AGNT; Mouser Price/Stock: https://www.mouser.co.uk/ProductDetail/ECS/ECS-80-18-30B-AGN-TR?qs=wd5RIQLrsJheFNc7NZge4Q%3D%3D
